# Altium schematic sheet main.SchDoc
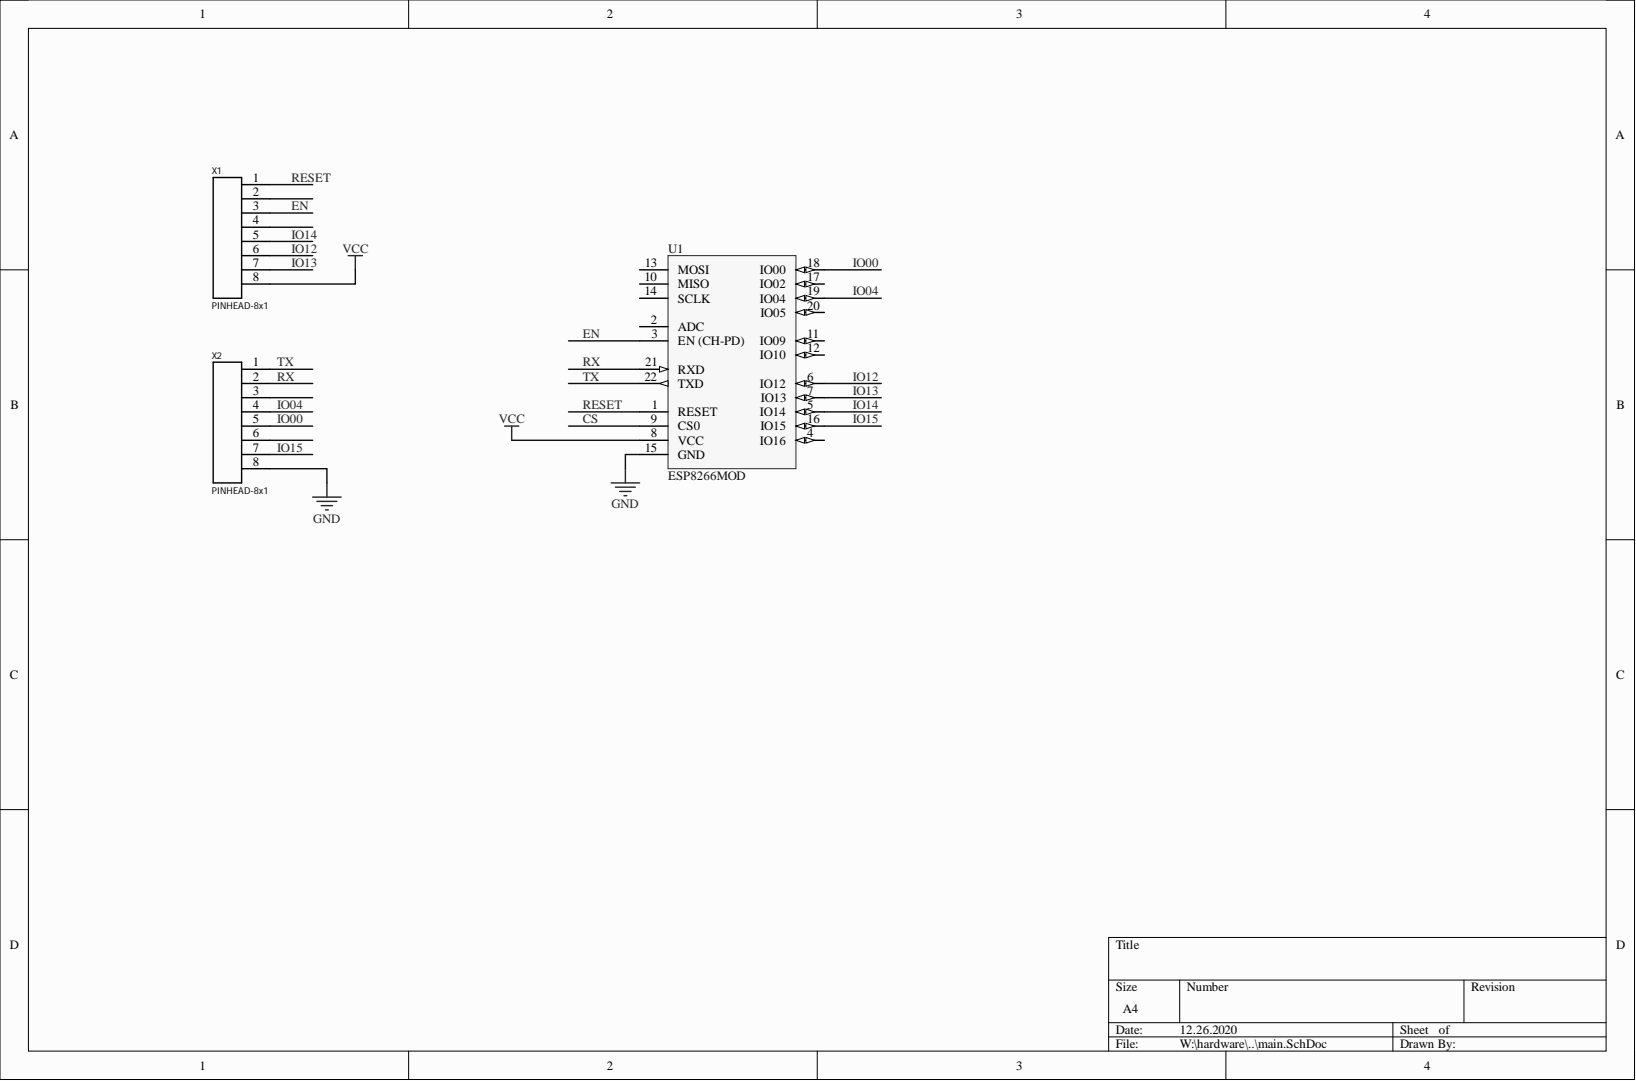
[diagram p0: main.SchDoc]

## Parts
U1  ESP8266MOD  [ESP-12E]
X1  PINHEAD-8x1  [PINHEAD-MALE-8x1]
X2  PINHEAD-8x1  [PINHEAD-MALE-8x1]

## Nets
GND: U1.15(GND) X2.8
VCC: U1.8(VCC) X1.8
CS: U1.9(CS0)
EN: U1.3(EN_(CH-PD)) X1.3
IO00: U1.18(IO00) X2.5
IO04: U1.19(IO04) X2.4
IO12: U1.6(IO12) X1.6
IO13: U1.7(IO13) X1.7
IO14: U1.5(IO14) X1.5
IO15: U1.16(IO15) X2.7
RESET: U1.1(RESET) X1.1
RX: U1.21(RXD) X2.2
TX: U1.22(TXD) X2.1

Not connected: U1 2(ADC) 4(IO16) 10(MISO) 11(IO09) 12(IO10) 13(MOSI) 14(SCLK) 17(IO02) 20(IO05); X1 2 4; X2 3 6

## Library data of the parts
U1 = ESP12E: Component Kind: Standard; Library Name: all-in-one.IntLib; value: ESP8266MOD
X1 X2 = PINHEAD-8x1: Component Kind: Standard; Library Name: all-in-one.IntLib
